# Altium schematic sheet F-Ethernet.SchDoc(F-Ethernet)
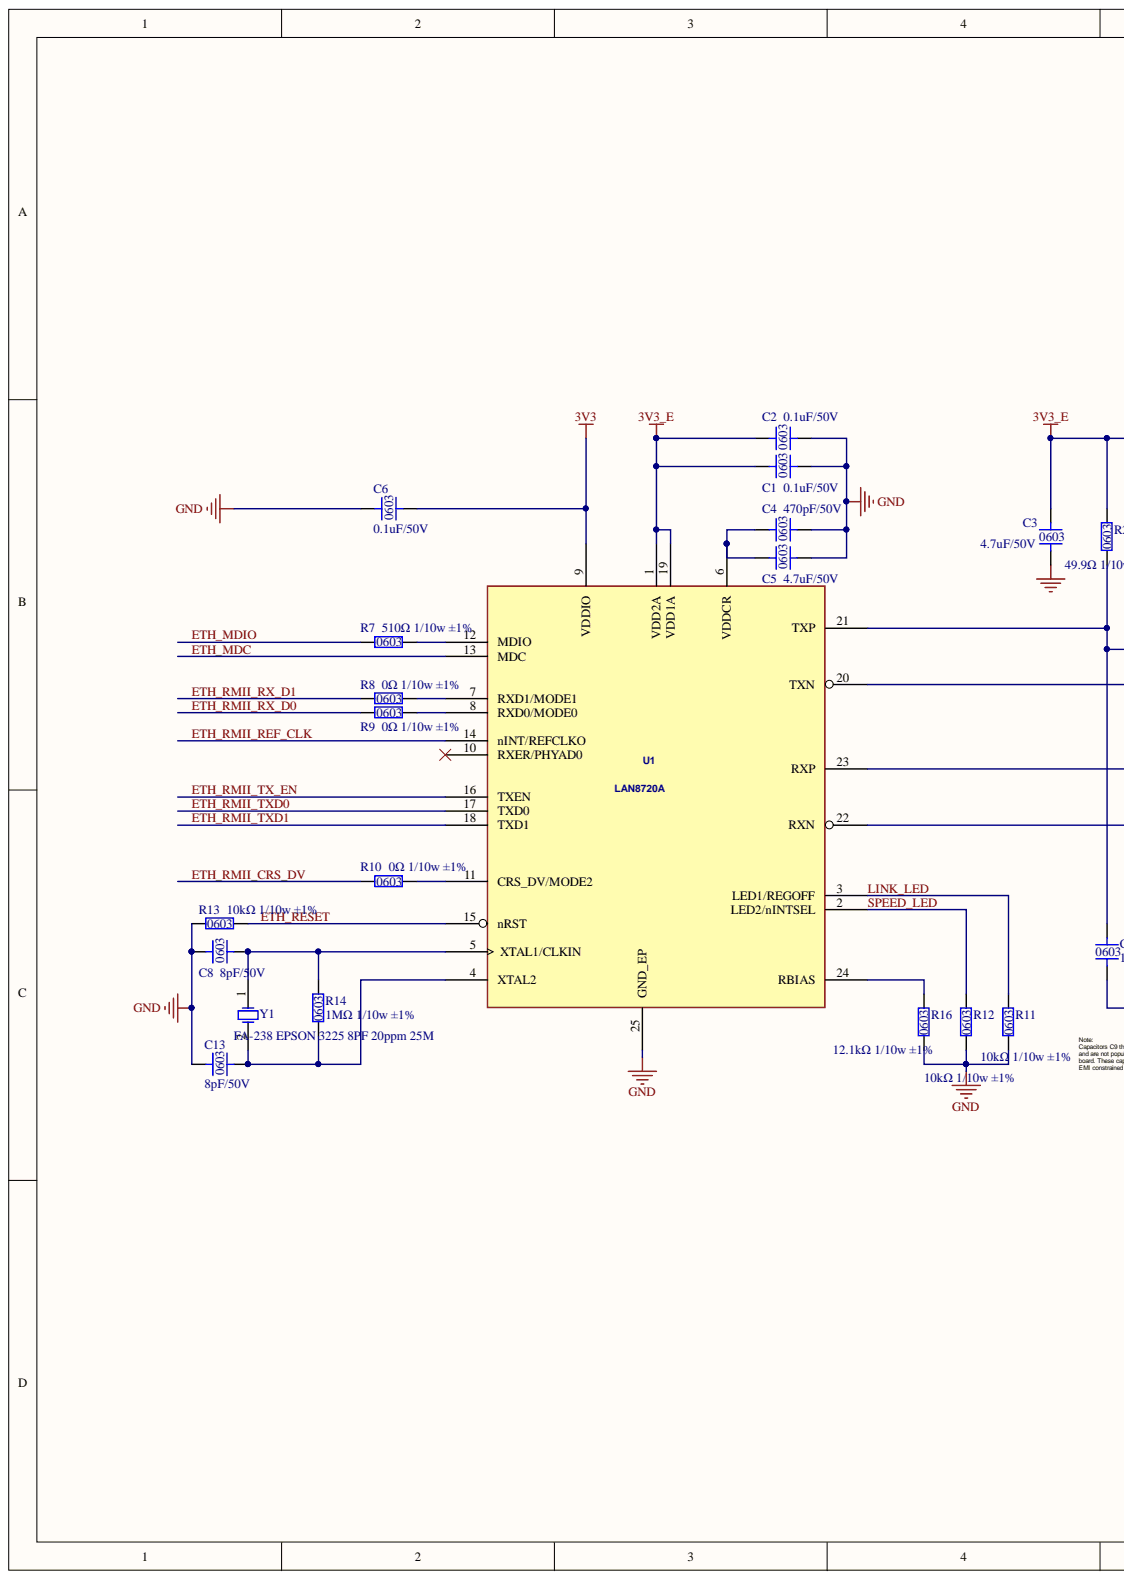
[diagram p0: F-Ethernet.SchDoc, left]
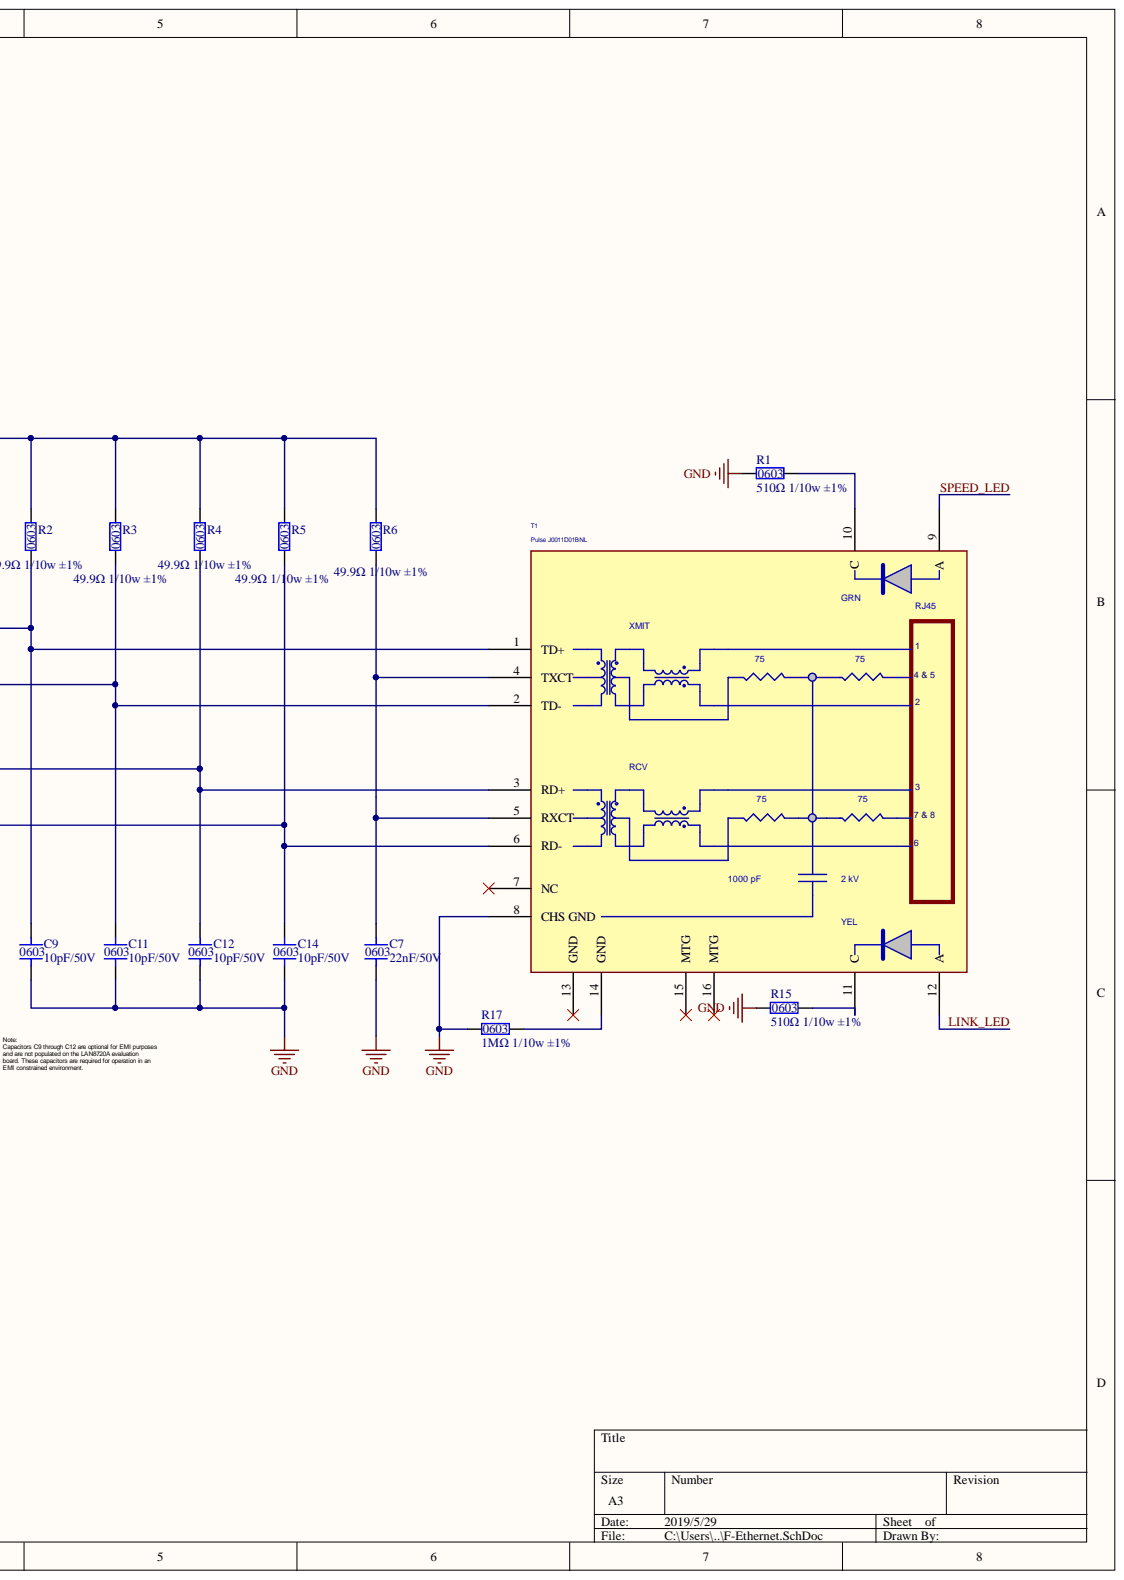
[diagram p1: F-Ethernet.SchDoc, right]

## Parts
C1  0.1uF/50V  [C-0603]
C2  0.1uF/50V  [C-0603]
C3  4.7uF/50V  [C-0603]
C4  470pF/50V  [C-0603]
C5  4.7uF/50V  [C-0603]
C6  0.1uF/50V  [C-0603]
C7  22nF/50V  [C-0603]
C8  8pF/50V  [C-0603]
C9  10pF/50V  [C-0603]
C11  10pF/50V  [C-0603]
C12  10pF/50V  [C-0603]
C13  8pF/50V  [C-0603]
C14  10pF/50V  [C-0603]
R1  510Ω 1/10w ±1%  [R-0603]
R2  49.9Ω 1/10w ±1%  [R-0603]
R3  49.9Ω 1/10w ±1%  [R-0603]
R4  49.9Ω 1/10w ±1%  [R-0603]
R5  49.9Ω 1/10w ±1%  [R-0603]
R6  49.9Ω 1/10w ±1%  [R-0603]
R7  510Ω 1/10w ±1%  [R-0603]
R8  0Ω 1/10w ±1%  [R-0603]
R9  0Ω 1/10w ±1%  [R-0603]
R10  0Ω 1/10w ±1%  [R-0603]
R11  10kΩ 1/10w ±1%  [R-0603]
R12  10kΩ 1/10w ±1%  [R-0603]
R13  10kΩ 1/10w ±1%  [R-0603]
R14  1MΩ 1/10w ±1%  [R-0603]
R15  510Ω 1/10w ±1%  [R-0603]
R16  12.1kΩ 1/10w ±1%  [R-0603]
R17  1MΩ 1/10w ±1%  [R-0603]
T1  Pulse - J0011D01BNL_RoHS  PART#: Pulse J0011D01BNL  [RJ45-3]
U1  LAN8720A  [QFN24]
Y1  FA-238 EPSON 3225 8PF 20ppm 25M  [3225XTAL]

## Nets
3V3: C6.1 U1.9(VDDIO)
3V3_E: C1.2 C2.2 C3.2 R2.2 R3.2 R4.2 R5.2 R6.2 U1.1(VDD2A) U1.19(VDD1A)
GND: C1.1 C2.1 C3.1 C4.1 C5.1 C6.2 C7.1 C8.2 C9.1 C11.1 C12.1 C13.2 C14.1 R1.1 R11.1 R12.1 R13.1 R15.1 R16.1 R17.1 T1.8(CHS_GND) U1.25(GND_EP)
ETH_MDC: U1.13(MDC)
ETH_MDIO: R7.1
ETH_RESET: R13.2 U1.15(nRST)
ETH_RMII_CRS_DV: R10.1
ETH_RMII_REF_CLK: U1.14(nINT/REFCLKO)
ETH_RMII_RX_D0: R9.1
ETH_RMII_RX_D1: R8.1
ETH_RMII_TX_EN: U1.16(TXEN)
ETH_RMII_TXD0: U1.17(TXD0)
ETH_RMII_TXD1: U1.18(TXD1)
LINK_LED: R11.2 T1.12(A) U1.3(LED1/REGOFF)
SPEED_LED: R12.2 T1.9(A) U1.2(LED2/nINTSEL)
NetC4_2: C4.2 C5.2 U1.6(VDDCR)
NetC7_2: C7.2 R6.1 T1.4(TXCT) T1.5(RXCT)
NetC8_1: C8.1 R14.2 U1.5(XTAL1/CLKIN) Y1.1
NetC9_2: C9.2 R2.1 T1.1(TD+) U1.21(TXP)
NetC11_2: C11.2 R3.1 T1.2(TD-) U1.20(TXN)
NetC12_2: C12.2 R4.1 T1.3(RD+) U1.23(RXP)
NetC13_1: C13.1 R14.1 U1.4(XTAL2) Y1.2
NetC14_2: C14.2 R5.1 T1.6(RD-) U1.22(RXN)
NetR1_2: R1.2 T1.10(C)
NetR7_2: R7.2 U1.12(MDIO)
NetR8_2: R8.2 U1.7(RXD1/MODE1)
NetR9_2: R9.2 U1.8(RXD0/MODE0)
NetR10_2: R10.2 U1.11(CRS_DV/MODE2)
NetR15_2: R15.2 T1.11(C)
NetR16_2: R16.2 U1.24(RBIAS)
NetR17_2: R17.2 T1.14(GND)

Not connected: T1 7(NC) 13(GND) 15(MTG) 16(MTG); U1 10(RXER/PHYAD0)

## Library data of the parts
C1-C9 C11-C14 = 10uF/50V: Component Kind: Standard; Library Name: dahuan.SchLib
R1-R17 = 2kΩ 1/10w ±1%: Component Kind: Standard; Library Name: dahuan.SchLib
U1 = LAN8720 QFN24: PART#: SMSC LAN8720A-CP; Component Kind: Standard
Y1 = XTAL: Crystal Oscillator; Component Kind: Standard; LatestRevisionDate: 17-Jul-2002; LatestRevisionNote: Re-released for DXP Platform.; Library Name: Miscellaneous Devices.IntLib; PackageDescription: Crystal, Thru-Hole; 2 Leads; Body 3.1 x 8.2 mm (Dia.xH); PackageReference: R38; Published: 8-Jun-2000; Simulation: XTAL; Publisher: Altium Limited
T1 = Pulse - J0011D01BNL_RoHS: Component Kind: Standard; RoHS: RoHS
